# Altium schematic sheet Power_Card.SchDoc
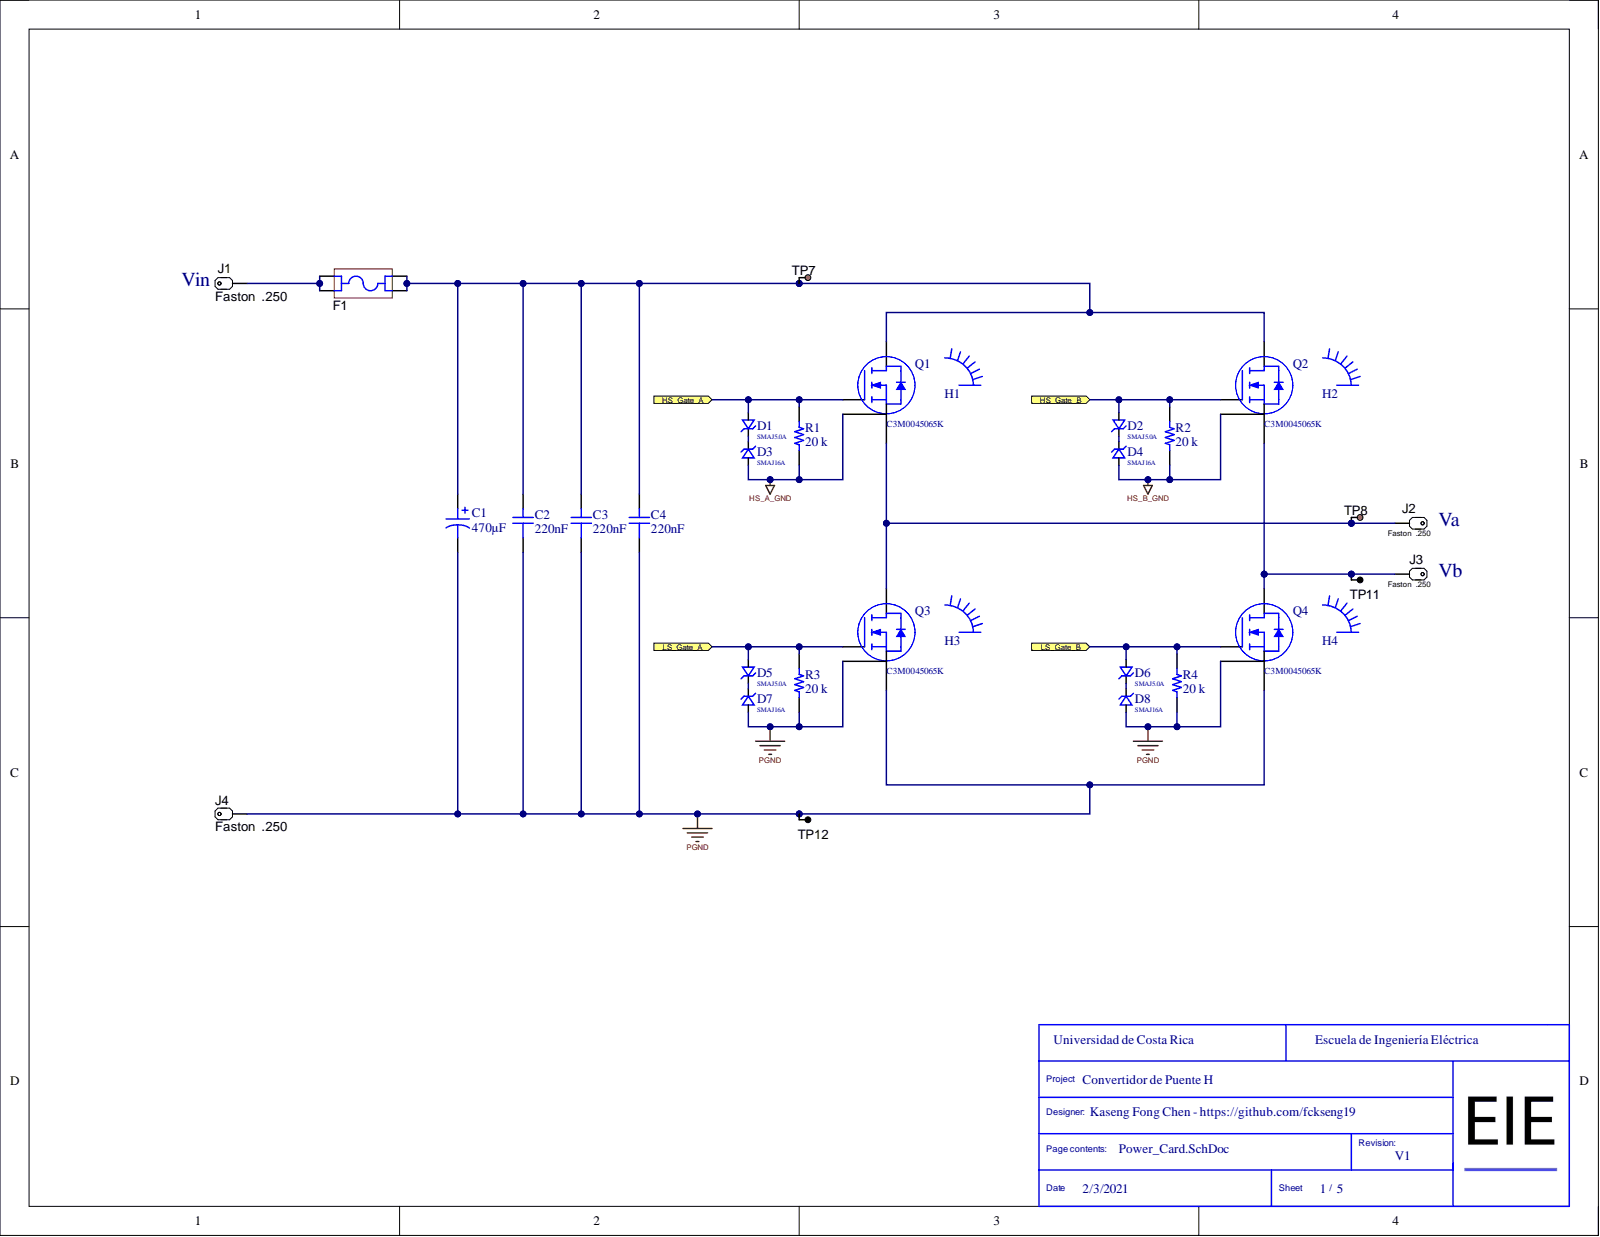
[diagram p0: Power_Card.SchDoc]

## Parts
C1  470µF  [CAP TH ALUM ELEC D35.00mm S10.00mm H40.00mm]
C2  220nF  [R75PI32204030J]
C3  220nF  [R75PI32204030J]
C4  220nF  [R75PI32204030J]
D1  SMAJ5.0A  [DO214AC SMA]
D2  SMAJ5.0A  [DO214AC SMA]
D3  SMAJ16A  [DO214AC SMA]
D4  SMAJ16A  [DO214AC SMA]
D5  SMAJ5.0A  [DO214AC SMA]
D6  SMAJ5.0A  [DO214AC SMA]
D7  SMAJ16A  [DO214AC SMA]
D8  SMAJ16A  [DO214AC SMA]
F1  3101.0040  [SCHURTER_3101_0040]  (value not drawn)
H1  Disipador de 3.3.C/W  [HSINK_6399BG]  (value not drawn)
H2  Disipador de 3.3.C/W  [HSINK_6399BG]  (value not drawn)
H3  Disipador de 3.3.C/W  [HSINK_6399BG]  (value not drawn)
H4  Disipador de 3.3.C/W  [HSINK_6399BG]  (value not drawn)
J1  Faston  .250  [TE_62409-1]
J2  Faston  .250  [TE_62409-1]
J3  Faston  .250  [TE_62409-1]
J4  Faston  .250  [TE_62409-1]
Q1  C3M0045065K  [TO-247-4L_C3M0075120K_WFS]
Q2  C3M0045065K  [TO-247-4L_C3M0075120K_WFS]
Q3  C3M0045065K  [TO-247-4L_C3M0075120K_WFS]
Q4  C3M0045065K  [TO-247-4L_C3M0075120K_WFS]
R1  20 k  [RES 0603_1608]
R2  20 k  [RES 0603_1608]
R3  20 k  [RES 0603_1608]
R4  20 k  [RES 0603_1608]
TP7  5010  [Keystone5010]  (value not drawn)
TP8  5010  [Keystone5010]  (value not drawn)
TP11  5011  [Keystone5011]  (value not drawn)
TP12  5011  [Keystone5011]  (value not drawn)

## Ports
HS_Gate_A, HS_Gate_B, LS_Gate_A, LS_Gate_B

## Nets
HS_A_GND: D3.2 Q1.3 R1.1
HS_B_GND: D4.2 Q2.3 R2.1
PGND: C1.2 C2.2 C3.2 C4.2 D7.2 D8.2 J4.1 Q3.2 Q3.3 Q4.2 Q4.3 R3.1 R4.1 TP12.1
HS_Gate_A: D1.2 Q1.4 R1.2 ; port HS_Gate_A
HS_Gate_B: D2.2 Q2.4 R2.2 ; port HS_Gate_B
LS_Gate_A: D5.2 Q3.4 R3.2 ; port LS_Gate_A
LS_Gate_B: D6.2 Q4.4 R4.2 ; port LS_Gate_B
NetC1_1: C1.1 C2.1 C3.1 C4.1 F1.3 F1.4 Q1.1 Q2.1 TP7.1
NetD1_1: D1.1 D3.1
NetD2_1: D2.1 D4.1
NetD5_1: D5.1 D7.1
NetD6_1: D6.1 D8.1
NetF1_1: F1.1 F1.2 J1.1
NetJ2_1: J2.1 Q1.2 Q3.1 TP8.1
NetJ3_1: J3.1 Q2.2 Q4.1 TP11.1

## Library data of the parts
C1 = ESMQ451VSN471MA40S: CAP ALUM 470UF 20% 450V SNAP; Manufacturer: United Chemi-Con; Database Table Name: Capacitors - Aluminum - Electrolytic TH; Lifetime @ Temp.: 2000 Hrs @ 85°C; Polarization: Polar; Ripple Current @ High Frequency: 3.618A @ 50kHz; Ripple Current @ Low Frequency: 2.53A @ 120Hz; Applications: General Purpose; Capacitance: 470µF; Component Kind: Standard; ComponentLink1Description: Datasheet; ComponentLink1URL: http://www.chemi-con.co.jp/cgi-bin/CAT_DB/SEARCH/cat_db_al.cgi?e=e&j=p&pdfname=smqlug; ComponentLink2Description: DigiKey Link; ComponentLink2URL: http://digikey.com/product-detail/en/united-chemi-con/ESMQ451VSN471MA40S/565-2798-ND/758010; Device Package: Radial, Can - Snap-In; Height - Seated (Max): 1.575" (40.00mm); LastUpdated: 13/9/2020 09:22:08; Lead Spacing: 0.394" (10.00mm); Library Name: Celestial Altium Library - altium_library.DbLib; Lifecycle Status: Active; Minimum Order: 1; Mounting Type: Through Hole; Operating Temperature: -25°C ~ 85°C; Package / Case: Radial, Can - Snap-In; Packaging: Bulk; Part Number: ESMQ451VSN471MA40S; Part Status: Active; PartId: 26446; Price: 5.99; Series: SMQ; Size / Dimension: 1.378" Dia (35.00mm); Supplier 1: DigiKey; Supplier Part Number 1: 565-2798-ND; Tolerance: ±20%; Value: 470µF; Voltage - Rated: 450V
C2-C4 = R75PI32204030J: KEMET         R75PI32204030J            Film Capacitor, AEC-Q200 R75 Series, 0.22 F,  5%, PP (Polypropylene), 630 V; Dissipation Factor: 0.05%; Lead Diameter: 0.8mm; Lead Length: 30mm; Voltage: 630V; Voltage Rating (AC): 250V; Capacitance: 220nF; Case/Package: Radial; Category: Film Capacitors; Component Kind: Standard; ComponentLink1Description: http://www.alliedelec.com/m/d/0659d349de7ca29105b1b70da899f791.pdf?src-supplier=Allied+Electronics; ComponentLink1URL: http://www.alliedelec.com/m/d/0659d349de7ca29105b1b70da899f791.pdf?src-supplier=Allied+Electronics; ComponentLink2Description: http://www.farnell.com/datasheets/2120989.pdf?src-supplier=Farnell; ComponentLink2URL: http://www.farnell.com/datasheets/2120989.pdf?src-supplier=Farnell; ComponentLink3Description: http://www.kemet.com/docfinder?Partnumber=R75PI32204030J&src-supplier=Digi-Key; ComponentLink3URL: http://www.kemet.com/docfinder?Partnumber=R75PI32204030J&src-supplier=Digi-Key; ComponentLink4Description: http://www.farnell.com/datasheets/2068064.pdf?src-supplier=Element14; ComponentLink4URL: http://www.farnell.com/datasheets/2068064.pdf?src-supplier=Element14; Depth: 10mm; Dielectric: PP; Dielectric Material: PP; Height: 16mm; Height - Seated (Max): 0.634inch; Lead Pitch: 15mm; Length: 0.709inch; Manufacturer: KEMET; Manufacturer Part Number: R75PI32204030J; Max Operating Temperature: 105°C; Min Operating Temperature: -55°C; Mount: Through Hole; Packaging: Bulk; Pricing 1: 1=0,95000, 10=0,72000, 50=0,59800, 100=0,51490, 500=0,39860, 1000=0,34324, 2500=0,32110, 5000=0,31002 (USD); REACH SVHC: No SVHC; RoHS: Yes; RoHS Compliant: Yes; Stock 1: 488; Supplier 1: Digi-Key; Supplier Part Number 1: 399-12761-ND; Termination: PC Pins; Tolerance: 5%; Voltage Rating: 630V; Voltage Rating (DC): 630V; Width: 0.394inch; Library Name: kasengLib.IntLib
D1 D2 D5 D6 = SMAJ5.0A: BOURNS - SMAJ5.0A - TVS Diode, SMAJ Series, Unidirectional, 5 V, 9.2 V, DO-214AC (SMA), 2 Pins; Breakdown Voltage: 7V; Clamping Voltage: 9.2V; Diode Type: ZENER; Element Configuration: Single; ESD Protection: Yes; Leakage Current: 800uA; Max Power Dissipation: 400W; Max Surge Current: 40A; Min Breakdown Voltage: 6.4V; Number of Channels: 1; Operating Supply Voltage: 3.5V; Peak Pulse Current: 43.5A; Power Line Protection: No; Reverse Standoff Voltage: 5V; Test Current: 10mA; Case/Package: DO-214AC; Category: TVS Diodes; Component Kind: Standard; ComponentLink1Description: https://datasheet.ciiva.com/25624/0900766b8112213b-25624680.pdf?src-supplier=RSComponents; ComponentLink1URL: https://datasheet.ciiva.com/25624/0900766b8112213b-25624680.pdf?src-supplier=RSComponents; ComponentLink2Description: https://datasheet.ciiva.com/333/smaj-333521.pdf?src-supplier=Digi-Key; ComponentLink2URL: https://datasheet.ciiva.com/333/smaj-333521.pdf?src-supplier=Digi-Key; ComponentLink3Description: https://datasheet.ciiva.com/333/smaj-333522.pdf?src-supplier=Digi-Key; ComponentLink3URL: https://datasheet.ciiva.com/333/smaj-333522.pdf?src-supplier=Digi-Key; ComponentLink4Description: https://datasheet.ciiva.com/333/smaj-333523.pdf?src-supplier=Digi-Key; ComponentLink4URL: https://datasheet.ciiva.com/333/smaj-333523.pdf?src-supplier=Digi-Key; ComponentLink5Description: https://datasheet.ciiva.com/3021/smaj-3021400.pdf?src-supplier=Avnet; ComponentLink5URL: https://datasheet.ciiva.com/3021/smaj-3021400.pdf?src-supplier=Avnet; ComponentLink6Description: https://datasheet.ciiva.com/30169/smaj-30169929.pdf?src-supplier=Onlinecomponents.com; ComponentLink6URL: https://datasheet.ciiva.com/30169/smaj-30169929.pdf?src-supplier=Onlinecomponents.com; ComponentLink7Description: https://datasheet.ciiva.com/28409/1695388-28409916.pdf?src-supplier=Element14; ComponentLink7URL: https://datasheet.ciiva.com/28409/1695388-28409916.pdf?src-supplier=Element14; Direction: Unidirectional; Height: 2.42mm; Length: 4.57mm; Manufacturer: Bourns; Manufacturer Part Number: SMAJ5.0A; Max Breakdown Voltage: 7V; Max Operating Temperature: 150°C; Max Reverse Leakage Current: 800uA; Min Operating Temperature: -55°C; Mount: Surface Mount; Number of Elements: 1; Number of Pins: 2; Number of Unidirectional Channels: 1; Package Quantity: 1; Packaging: Tape and Reel; Peak Pulse Power: 400W; Polarity: Unidirectional; Pricing 1: 1=0,49000, 10=0,34300, 100=0,17320, 500=0,14130, 1000=0,10483, 2000=0,08820 (USD); Radiation Hardening: No; REACH SVHC: No SVHC; Resistor Type: Zener; Reverse Breakdown Voltage: 6.4V; RoHS: Yes; RoHS Compliant: Yes; Series: SMAJ; Stock 1: 30981; Supplier 1: Digi-Key; Supplier Part Number 1: SMAJ5.0ABCT-ND; Termination: SMD/SMT; Width: 2.92mm; Library Name: KasengLIb.SchLib
D3 D4 D7 D8 = SMAJ16A: TVS DIODE 16VWM 26VC DO214AC; Manufacturer: Bourns; Breakdown Voltage: 19.7V; Clamping Voltage: 26V; Diode Type: ZENER; Direction: Unidirectional; Element Configuration: Single; Leakage Current: 5uA; Max Power Dissipation: 400W; Max Surge Current: 40A; Min Breakdown Voltage: 17.8V; Number of Channels: 1; Operating Supply Voltage: 3.5V; Peak Pulse Current: 15.3A; Power Line Protection: No; Reverse Standoff Voltage: 16V; Test Current: 1mA; Case/Package: DO-214AC; Category: TVS Diodes; Component Kind: Standard; ComponentLink1Description: https://datasheet.ciiva.com/3022/smaj-3022194.pdf?src-supplier=Avnet; ComponentLink1URL: https://datasheet.ciiva.com/3022/smaj-3022194.pdf?src-supplier=Avnet; ComponentLink2Description: https://datasheet.ciiva.com/3022/smaj-3022195.pdf?src-supplier=Avnet; ComponentLink2URL: https://datasheet.ciiva.com/3022/smaj-3022195.pdf?src-supplier=Avnet; ComponentLink3Description: https://datasheet.ciiva.com/8031/1695388-8031131.pdf?src-supplier=Element14; ComponentLink3URL: https://datasheet.ciiva.com/8031/1695388-8031131.pdf?src-supplier=Element14; ComponentLink4Description: https://datasheet.ciiva.com/28649/1695388-28649163.pdf?src-supplier=Element14; ComponentLink4URL: https://datasheet.ciiva.com/28649/1695388-28649163.pdf?src-supplier=Element14; ComponentLink5Description: https://datasheet.ciiva.com/333/smaj-333452.pdf?src-supplier=Digi-Key; ComponentLink5URL: https://datasheet.ciiva.com/333/smaj-333452.pdf?src-supplier=Digi-Key; ComponentLink6Description: https://datasheet.ciiva.com/333/smaj-333453.pdf?src-supplier=Digi-Key; ComponentLink6URL: https://datasheet.ciiva.com/333/smaj-333453.pdf?src-supplier=Digi-Key; ComponentLink7Description: https://datasheet.ciiva.com/17413/smaj-17413677.pdf?src-supplier=Avnet+Europe; ComponentLink7URL: https://datasheet.ciiva.com/17413/smaj-17413677.pdf?src-supplier=Avnet+Europe; ComponentLink8Description: https://datasheet.ciiva.com/333/smaj-333454.pdf?src-supplier=Digi-Key; ComponentLink8URL: https://datasheet.ciiva.com/333/smaj-333454.pdf?src-supplier=Digi-Key; ComponentLink9Description: https://datasheet.ciiva.com/16237/smaj-16237406.pdf?src-supplier=Onlinecomponents.com; ComponentLink9URL: https://datasheet.ciiva.com/16237/smaj-16237406.pdf?src-supplier=Onlinecomponents.com; Manufacturer Part Number: SMAJ16A; Max Breakdown Voltage: 19.7V; Max Operating Temperature: 150°C; Max Reverse Leakage Current: 5uA; Min Operating Temperature: -55°C; Mount: Surface Mount; Number of Elements: 1; Number of Pins: 2; Number of Unidirectional Channels: 1; Package Quantity: 1; Packaging: Tape and Reel; Peak Pulse Power: 400W; Polarity: Unidirectional; Pricing 1: 1=0,49000, 10=0,34400, 100=0,17360, 500=0,14160, 1000=0,10505, 2000=0,08838 (USD); Radiation Hardening: No; REACH SVHC: No SVHC; Resistor Type: Zener; Reverse Breakdown Voltage: 17.8V; RoHS: Yes; RoHS Compliant: Yes; Series: SMAJ; Stock 1: 14121; Supplier 1: Digi-Key; Supplier Part Number 1: SMAJ16ABCT-ND; Termination: SMD/SMT; Library Name: KasengLIb.SchLib
F1 = 3101.0040: Fuse Holder, 400 V, 16 A, TH; Manufacturer: Schurter; SymbolCreator: a0799628; Component Kind: Standard; ComponentLink1Description: Datasheet Link; ComponentLink1URL: http://www.schurterinc.com/var/schurter/storage/ilcatalogue/files/document/datasheet/en/pdf/typ_FPG4.pdf; CompType: Fuse Holder; LatestRevisionDate: 2016-05-10; MountType: TH; PackageReference: Fuse Holder for 5x20mm; PartNumber: 3101.0040; RoHS: Y; Supplier 1: Digi-Key; Supplier 2: Mouser; Supplier 3: Farnell; Supplier Part Number 1: 486-1159-ND; Supplier Part Number 2: 693-3101.0040; Supplier Part Number 3: 1222690; SymbolVersion: 2014-05-01; Library Name: kasengLib.IntLib; Publisher: Texas Instruments
H1-H4 = 6399BG: AAVID THERMALLOY         6399BG            Heat Sink, TO-220/218, TO-220, 3.3 C/W, 50 mm, 41.91 mm, 25.4 mm; Finish: Anodized; Natural Thermal Resistance: 3.3°C/W; Case/Package: TO-220; Category: Heat Sinks; Color: Black; Component Kind: Standard; ComponentLink1Description: https://datasheet.ciiva.com/26826/getdatasheetpartid-556672-26826808.pdf?src-supplier=Verical; ComponentLink1URL: https://datasheet.ciiva.com/26826/getdatasheetpartid-556672-26826808.pdf?src-supplier=Verical; ComponentLink2Description: https://datasheet.ciiva.com/16401/aavid20thermalloy206399bg-16401195.pdf?src-supplier=Future+Electronics; ComponentLink2URL: https://datasheet.ciiva.com/16401/aavid20thermalloy206399bg-16401195.pdf?src-supplier=Future+Electronics; Depth: 25.4mm; Height: 50.8mm; Lead Free: Lead Free; Length: 41.91mm; Manufacturer: Aavid Thermalloy; Manufacturer Part Number: 6399BG; Material: Anodized; Package Quantity: 250; Pricing 1: 1=6,20000, 10=6,02800, 25=5,69320, 50=5,35840, 100=5,02350, 250=4,68860, 500=4,35370, 1000=4,26998, 5000=4,18625 (USD); Radiation Hardening: No; REACH SVHC: No SVHC; RoHS: Yes; RoHS Compliant: Yes; Stock 1: 1442; Supplier 1: Digi-Key; Supplier Part Number 1: HS528-ND; Type: Passive; Width: 41.91mm; Library Name: kasengLib.IntLib
J1-J4 = 62409-1: Quick Disconnect Terminal M 15.8mm 7.5mm Tin Over Copper Automotive Bag; Manufacturer: AMP - TE CONNECTIVITY; Component Kind: Standard; ComponentLink1Description: http://www.farnell.com/datasheets/1650963.pdf?src-supplier=Farnell; ComponentLink1URL: http://www.farnell.com/datasheets/1650963.pdf?src-supplier=Farnell; ComponentLink2Description: http://www.farnell.com/datasheets/1650963.pdf?src-supplier=Element14; ComponentLink2URL: http://www.farnell.com/datasheets/1650963.pdf?src-supplier=Element14; ComponentLink3Description: http://www.farnell.com/datasheets/1650963.pdf?src-supplier=Newark; ComponentLink3URL: http://www.farnell.com/datasheets/1650963.pdf?src-supplier=Newark; ComponentLink4Description: https://www.te.com/commerce/DocumentDelivery/DDEController?Action=srchrtrv&DocNm=62409&DocType=Customer+Drawing&DocLang=English&src-supplier=Digi-Key; ComponentLink4URL: https://www.te.com/commerce/DocumentDelivery/DDEController?Action=srchrtrv&DocNm=62409&DocType=Customer+Drawing&DocLang=English&src-supplier=Digi-Key; Manufacturer Part Number: 62409-1; Pricing 1: 1=0,19000, 10=0,17800, 25=0,15200, 50=0,13320, 100=0,12360, 250=0,10936, 750=0,09035, 1500=0,08083, 8000=0,06895 (USD); RoHS: No; Stock 1: 8729; Supplier 1: Digi-Key; Supplier Part Number 1: A24742-ND; Library Name: kasengLib.IntLib
Q1-Q4 = C3M0045065K: Sic MOSFET 650V; Manufacturer: Cree Wolfspeed; Component Kind: Standard; Manufacturer Part Number: C3M0045065K; Pricing 1: 1=10,55000 (USD); RoHS: No; Stock 1: 444; Supplier 1: Digi-Key; Supplier Part Number 1: 1697-C3M0045065K-ND; Library Name: kasengLib.IntLib
R1-R4 = RC0603FR-0720KL: RES SMD 20K OHM 1% 1/10W 0603; Manufacturer: Yageo; Database Table Name: Resistors - Surface Mount - 0603; Power (Watts): 0.1W, 1/10W; Resistance: 20 kOhms; Temperature Coefficient: ±100ppm/°C; Component Kind: Standard; ComponentLink1Description: Datasheet; ComponentLink1URL: https://www.yageo.com/upload/media/product/productsearch/datasheet/rchip/PYu-RC_Group_51_RoHS_L_10.pdf; ComponentLink2Description: DigiKey Link; ComponentLink2URL: http://digikey.com/product-detail/en/yageo/RC0603FR-0720KL/311-20.0KHRCT-ND/729987; Composition: Thick Film; Device Package: 0603 (1608 Metric); Features: Moisture Resistant; Height - Seated (Max): 0.022" (0.55mm); LastUpdated: 2/9/2020 01:09:40; Library Name: Celestial Altium Library - altium_library.DbLib; Lifecycle Status: Active; Minimum Order: 1; Number of Terminations: 2; Operating Temperature: -55°C ~ 155°C; Package / Case: 0603 (1608 Metric); Packaging: CutTape; Part Number: RC0603FR-0720KL; Part Status: Active; PartId: 23954; Price: 0.10; Series: RC; Size / Dimension: 0.063" L x 0.031" W (1.60mm x 0.80mm); Supplier 1: DigiKey; Supplier Device Package: 0603; Supplier Part Number 1: 311-20.0KHRCT-ND; Tolerance: ±1%; Value: 20 k
TP7 TP8 = 5010: Test Point, Multipurpose, Red, TH; Manufacturer: Keystone; CompType: Test Point Loop; ContactFinish: Silver; Color: Red; Component Kind: Standard; ComponentLink1Description: Datasheet URL; ComponentLink1URL: http://www.keyelco.com/userAssets/file/M60-2p60.pdf; LatestRevisionDate: 2016-02-11; MountType: TH; PackageReference: Red Multipurpose Testpoint; PartNumber: 5010; RoHS: Y; Supplier 1: Digi-Key; Supplier 2: Mouser; Supplier Part Number 1: 36-5010-ND; Supplier Part Number 2: 534-5010; SymbolVersion: 2008-04-04; Library Name: kasengLib.IntLib; Publisher: Texas Instruments
TP11 TP12 = 5011: Test Point, Multipurpose, Black, TH; Manufacturer: Keystone; CompType: Test Point Loop; ContactFinish: Silver; Color: Black; Component Kind: Standard; ComponentLink1Description: Datasheet URL; ComponentLink1URL: http://www.keyelco.com/userAssets/file/M60-2p60.pdf; LatestRevisionDate: 2016-02-11; MountType: TH; PackageReference: Black Multipurpose Testpoint; PartNumber: 5011; RoHS: Y; Supplier 1: Digi-Key; Supplier 2: Mouser; Supplier Part Number 1: 36-5011-ND; Supplier Part Number 2: 534-5011; SymbolVersion: 2008-04-04; Library Name: kasengLib.IntLib; Publisher: Texas Instruments
